# Altium schematic sheet TOP.SchDoc
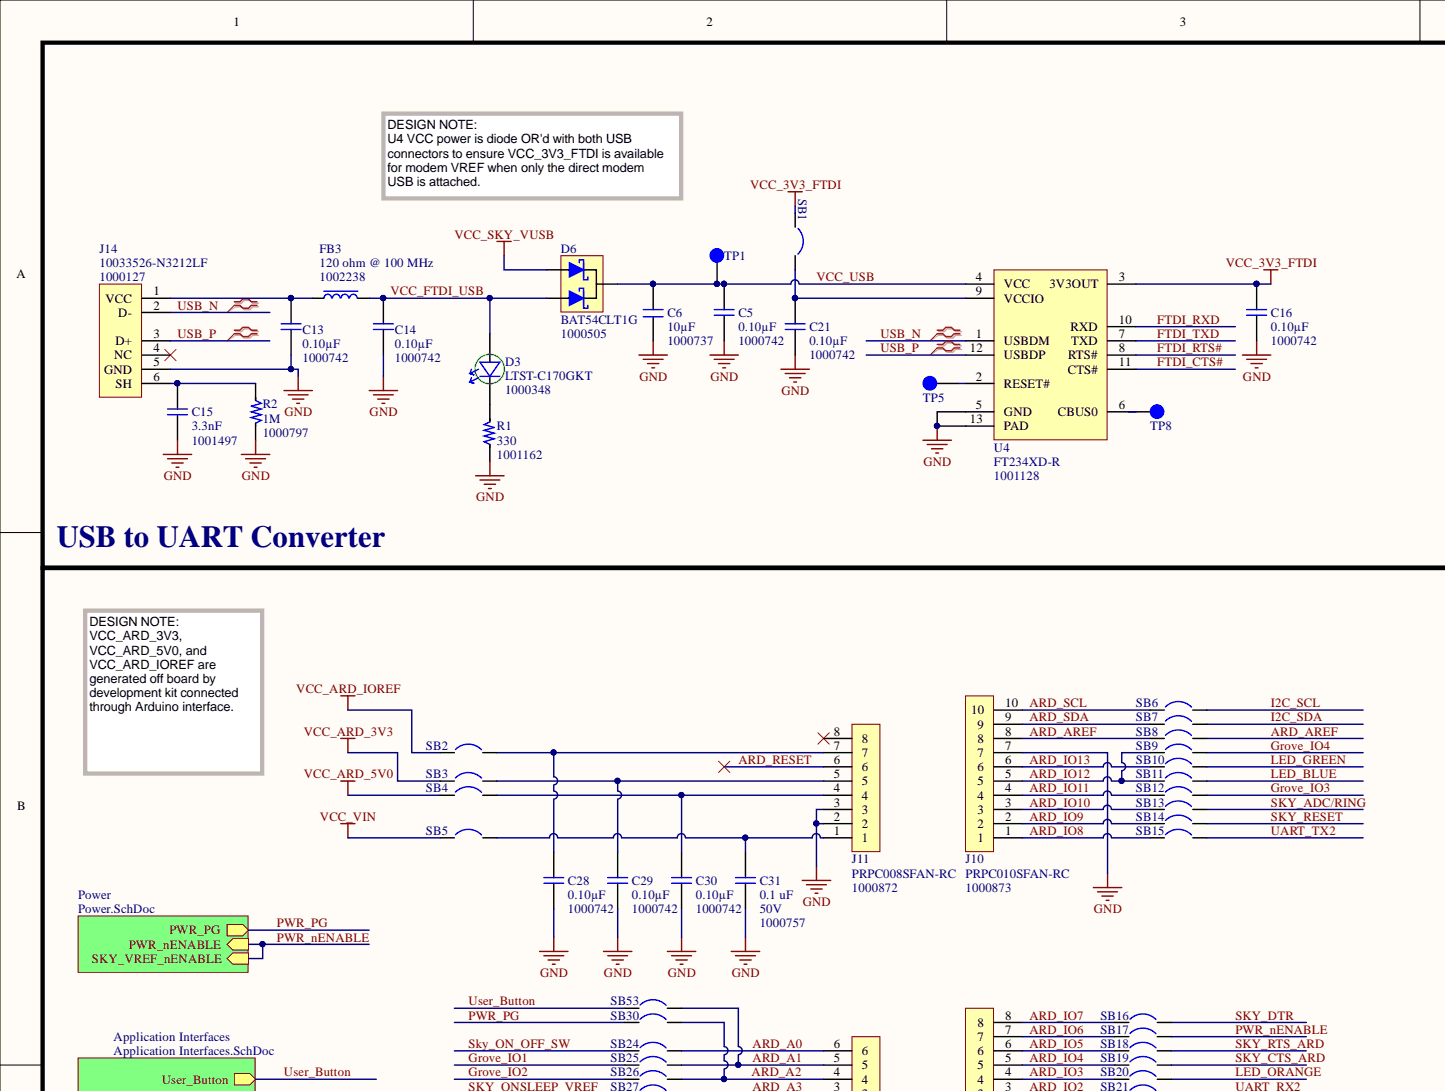
[diagram p0: TOP.SchDoc, top left]
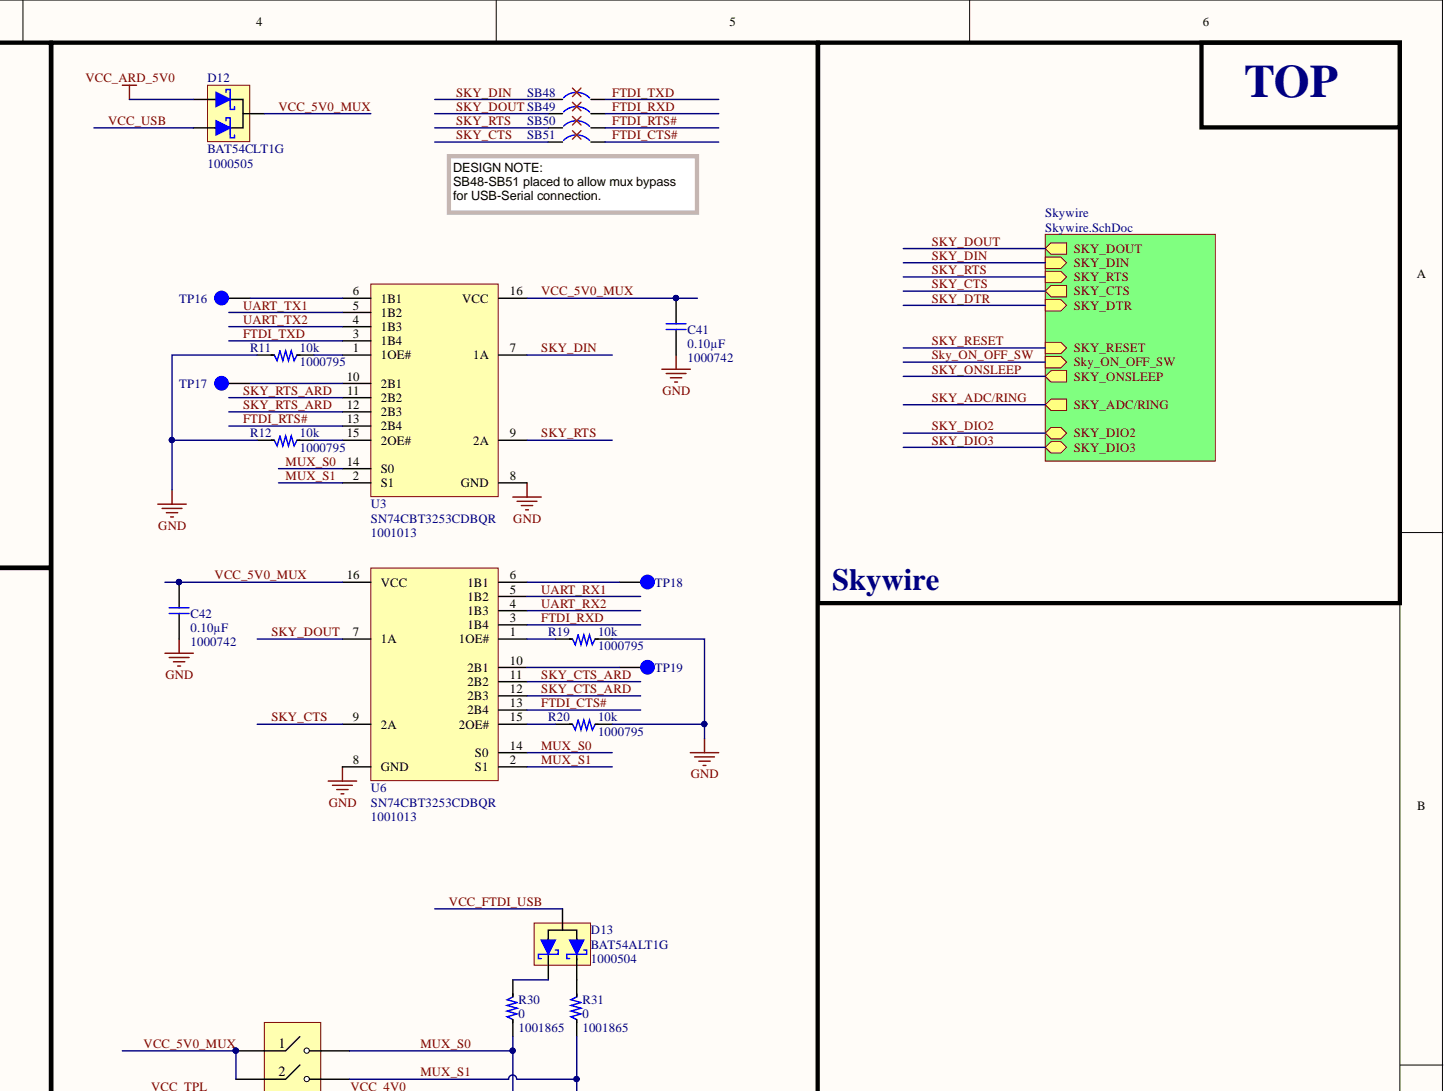
[diagram p1: TOP.SchDoc, top right]
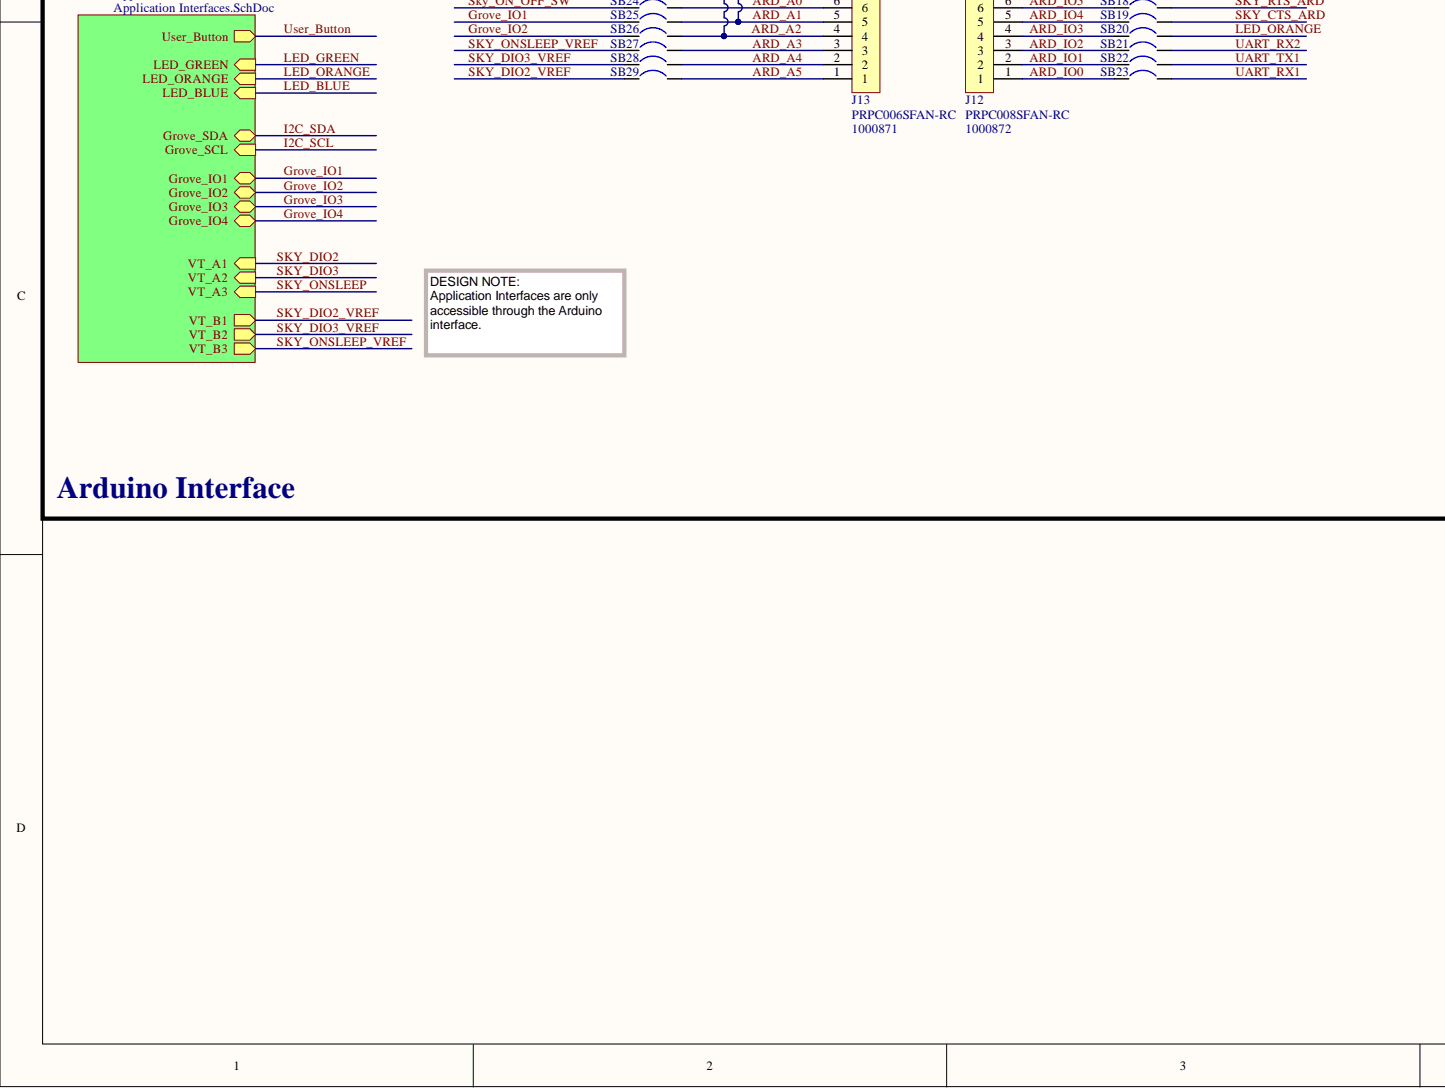
[diagram p2: TOP.SchDoc, bottom left]
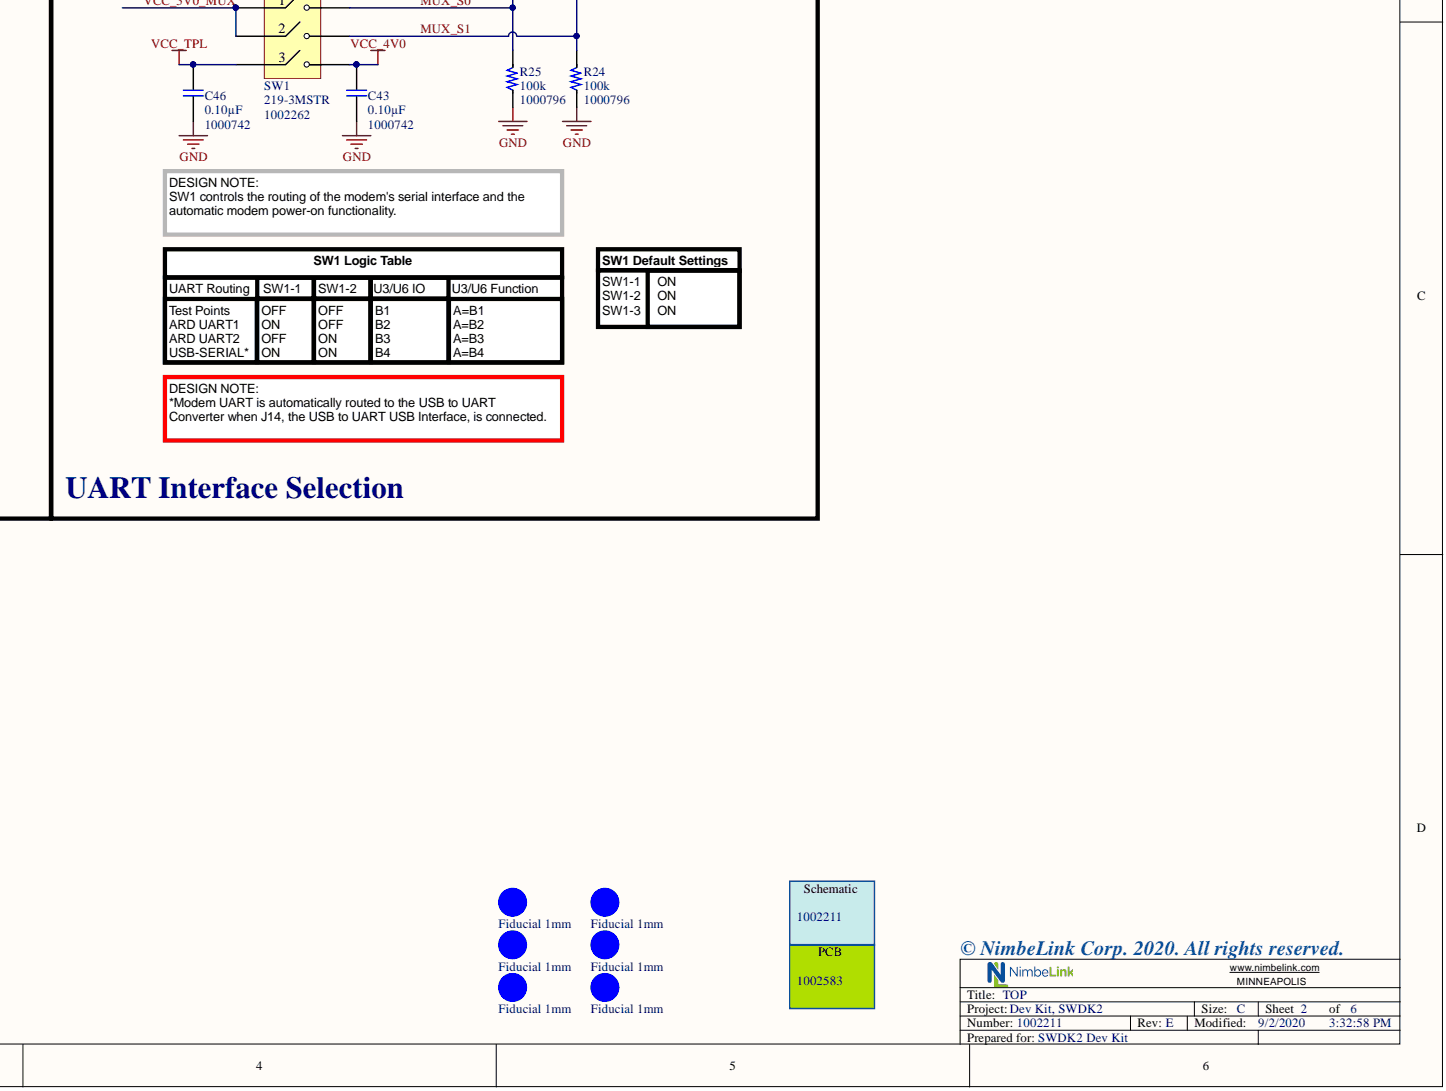
[diagram p3: TOP.SchDoc, bottom right]

## Parts
C5  Capacitance: 0.10µF  [0402]
C6  Capacitance: 10µF  [0402 +-0.2mm Width]  (hidden comment: C, 10 uF, 10V, X5R, 20%, 0402 CER)
C13  Capacitance: 0.10µF  [0402]
C14  Capacitance: 0.10µF  [0402]
C15  Capacitance: 3.3nF  [0402]  (hidden comment: CAPACITOR)
C16  Capacitance: 0.10µF  [0402]
C21  Capacitance: 0.10µF  [0402]
C28  Capacitance: 0.10µF  [0402]
C29  Capacitance: 0.10µF  [0402]
C30  Capacitance: 0.10µF  [0402]
C31  Capacitance: 0.1 uF  Voltage_Rating: 50V  [0603]  (hidden comment: CAPACITOR)
C41  Capacitance: 0.10µF  [0402]
C42  Capacitance: 0.10µF  [0402]
C43  Capacitance: 0.10µF  [0402]
C46  Capacitance: 0.10µF  [0402]
D3  LTST-C170GKT  [D_LED_GREEN]
D6  BAT54CLT1G  [SOT23-STYLE9]
D12  BAT54CLT1G  [SOT23-STYLE9]
D13  BAT54ALT1G  [SOT23-STYLE9]
FB3  FERRITE  [0603]  (value not drawn)
Fiducial1  Fiducial 1mm  [Fiducial 1mm]  (no BOM)
Fiducial2  Fiducial 1mm  [Fiducial 1mm]  (no BOM)
Fiducial3  Fiducial 1mm  [Fiducial 1mm]  (no BOM)
Fiducial4  Fiducial 1mm  [Fiducial 1mm]  (no BOM)
Fiducial5  Fiducial 1mm  [Fiducial 1mm]  (no BOM)
Fiducial6  Fiducial 1mm  [Fiducial 1mm]  (no BOM)
J10  PRPC010SFAN-RC  [PRPC010SFAN-RC]
J11  PRPC008SFAN-RC  [PRPC008SFAN-RC]
J12  PRPC008SFAN-RC  [PRPC008SFAN-RC]
J13  PRPC006SFAN-RC  [PRPC006SFAN-RC]
J14  USB MINI B  [10033526-N3212LF]  (value not drawn)
PCB1  PCB  Part_Number: 1002583  (mechanical)
R1  R, 330, 1%, 1/10W, 0402  [0402]  (value not drawn)
R2  Resistance_Ohms: 1M  [0402]  (hidden comment: R, 1M, 1%, 1/16W, 0402)
R11  Resistance_Ohms: 10k  [0402]  (hidden comment: R, 10K, 1%, 1/16W,  0402)
R12  Resistance_Ohms: 10k  [0402]  (hidden comment: R, 10K, 1%, 1/16W,  0402)
R19  Resistance_Ohms: 10k  [0402]  (hidden comment: R, 10K, 1%, 1/16W,  0402)
R20  Resistance_Ohms: 10k  [0402]  (hidden comment: R, 10K, 1%, 1/16W,  0402)
R24  Resistance_Ohms: 100k  [0402]  (hidden comment: R, 100K, 1%, 1/16W,  0402)
R25  Resistance_Ohms: 100k  [0402]  (hidden comment: R, 100K, 1%, 1/16W,  0402)
R30  RESISTOR  [0402]  (value not drawn)
R31  RESISTOR  [0402]  (value not drawn)
SB1  Solder Bridge  [Solder Bridge 0603 Closed]  (value not drawn)
SB2  Solder Bridge  [Solder Bridge 0603 Closed]  (value not drawn)
SB3  Solder Bridge  [Solder Bridge 0603 Closed]  (value not drawn)
SB4  Solder Bridge  [Solder Bridge 0603 Closed]  (value not drawn)
SB5  Solder Bridge  [Solder Bridge 0603 Closed]  (value not drawn)
SB6  Solder Bridge  [Solder Bridge 0603 Closed]  (value not drawn)
SB7  Solder Bridge  [Solder Bridge 0603 Closed]  (value not drawn)
SB8  Solder Bridge  [Solder Bridge 0603 Closed]  (value not drawn)
SB9  Solder Bridge  [Solder Bridge 0603 Closed]  (value not drawn)
SB10  Solder Bridge  [Solder Bridge 0603 Closed]  (value not drawn)
SB11  Solder Bridge  [Solder Bridge 0603 Closed]  (value not drawn)
SB12  Solder Bridge  [Solder Bridge 0603 Closed]  (value not drawn)
SB13  Solder Bridge  [Solder Bridge 0603 Closed]  (value not drawn)
SB14  Solder Bridge  [Solder Bridge 0603 Closed]  (value not drawn)
SB15  Solder Bridge  [Solder Bridge 0603 Closed]  (value not drawn)
SB16  Solder Bridge  [Solder Bridge 0603 Closed]  (value not drawn)
SB17  Solder Bridge  [Solder Bridge 0603 Closed]  (value not drawn)
SB18  Solder Bridge  [Solder Bridge 0603 Closed]  (value not drawn)
SB19  Solder Bridge  [Solder Bridge 0603 Closed]  (value not drawn)
SB20  Solder Bridge  [Solder Bridge 0603 Closed]  (value not drawn)
SB21  Solder Bridge  [Solder Bridge 0603 Closed]  (value not drawn)
SB22  Solder Bridge  [Solder Bridge 0603 Closed]  (value not drawn)
SB23  Solder Bridge  [Solder Bridge 0603 Closed]  (value not drawn)
SB24  Solder Bridge  [Solder Bridge 0603 Closed]  (value not drawn)
SB25  Solder Bridge  [Solder Bridge 0603 Closed]  (value not drawn)
SB26  Solder Bridge  [Solder Bridge 0603 Closed]  (value not drawn)
SB27  Solder Bridge  [Solder Bridge 0603 Closed]  (value not drawn)
SB28  Solder Bridge  [Solder Bridge 0603 Closed]  (value not drawn)
SB29  Solder Bridge  [Solder Bridge 0603 Closed]  (value not drawn)
SB30  Solder Bridge  [Solder Bridge 0603 Closed]  (value not drawn)
SB48  Solder Bridge  [SOLDER BRIDGE 0603]  (value not drawn)  (no BOM)
SB49  Solder Bridge  [SOLDER BRIDGE 0603]  (value not drawn)  (no BOM)
SB50  Solder Bridge  [SOLDER BRIDGE 0603]  (value not drawn)  (no BOM)
SB51  Solder Bridge  [SOLDER BRIDGE 0603]  (value not drawn)  (no BOM)
SB53  Solder Bridge  [Solder Bridge 0603 Closed]  (value not drawn)
SCH1  Part_Number: 1002211  (hidden comment: SCH)  (mechanical)
SW1  DIP-3pin  [219-3MSTR]  (value not drawn)
TP1  Test Point 1mm  [Test_Point 1mm]  (value not drawn)  (no BOM)
TP5  Test Point 1mm  [Test_Point 1mm]  (value not drawn)  (no BOM)
TP8  Test Point 1mm  [Test_Point 1mm]  (value not drawn)  (no BOM)
TP16  Test Point 1mm  [Test_Point 1mm]  (value not drawn)  (no BOM)
TP17  Test Point 1mm  [Test_Point 1mm]  (value not drawn)  (no BOM)
TP18  Test Point 1mm  [Test_Point 1mm]  (value not drawn)  (no BOM)
TP19  Test Point 1mm  [Test_Point 1mm]  (value not drawn)  (no BOM)
U3  SN74CBT3253CDBQR  [SN74CBT3253CDBQR]
U4  FT234XD  Manufacturer_Part_Number1: FT234XD-R  [QFN45P300X300X80_HS-13N]
U6  SN74CBT3253CDBQR  [SN74CBT3253CDBQR]

## Nets
GND: C5.2 C6.2 C13.2 C14.2 C15.1 C16.2 C21.2 C28.2 C29.2 C30.2 C31.2 C41.2 C42.2 C43.2 C46.2 J10.7 J11.2 J11.3 J14.5(GND) R1.1 R2.1 R11.2 R12.2 R19.1 R20.1 R24.1 R25.1 U3.8(GND) U4.5(GND) U4.13(PAD) U6.8(GND)
VCC_3V3_FTDI: C16.1 SB1.1 U4.3(3V3OUT)
VCC_4V0: C43.1 SW1.4
VCC_ARD_3V3: SB3.1
VCC_ARD_5V0: D12.2 SB4.1
VCC_ARD_IOREF: SB2.1
VCC_SKY_VUSB: D6.2
VCC_TPL: C46.1 SW1.3
VCC_VIN: SB5.1
ARD_A0: J13.6 SB24.2
ARD_A1: J13.5 SB25.2 SB53.2
ARD_A2: J13.4 SB26.2 SB30.2
ARD_A3: J13.3 SB27.2
ARD_A4: J13.2 SB28.2
ARD_A5: J13.1 SB29.2
ARD_AREF: J10.8 SB8.1 SB8.2
ARD_IO0: J12.1 SB23.1
ARD_IO1: J12.2 SB22.1
ARD_IO2: J12.3 SB21.1
ARD_IO3: J12.4 SB20.1
ARD_IO4: J12.5 SB19.1
ARD_IO5: J12.6 SB18.1
ARD_IO6: J12.7 SB17.1
ARD_IO7: J12.8 SB16.1
ARD_IO8: J10.1 SB15.1
ARD_IO9: J10.2 SB14.1
ARD_IO10: J10.3 SB13.1
ARD_IO11: J10.4 SB12.1
ARD_IO12: J10.5 SB9.1 SB11.1
ARD_IO13: J10.6 SB10.1
ARD_RESET: J11.6
ARD_SCL: J10.10 SB6.1
ARD_SDA: J10.9 SB7.1
FTDI_CTS#: SB51.2 U4.11(CTS#) U6.13(2B4)
FTDI_RTS#: SB50.2 U3.13(2B4) U4.8(RTS#)
FTDI_RXD: SB49.2 U4.10(RXD) U6.3(1B4)
FTDI_TXD: SB48.2 U3.3(1B4) U4.7(TXD)
Grove_IO1: SB25.1
Grove_IO2: SB26.1
Grove_IO3: SB12.2
Grove_IO4: SB9.2
I2C_SCL: SB6.2
I2C_SDA: SB7.2
LED_BLUE: SB11.2
LED_GREEN: SB10.2
LED_ORANGE: SB20.2
MUX_S0: R25.2 R30.1 SW1.6 U3.14(S0) U6.14(S0)
MUX_S1: R24.2 R31.1 SW1.5 U3.2(S1) U6.2(S1)
PWR_nENABLE: SB17.2
PWR_PG: SB30.1
SKY_ADC/RING: SB13.2
SKY_CTS: SB51.1 U6.9(2A)
SKY_CTS_ARD: SB19.2 U6.11(2B2) U6.12(2B3)
SKY_DIN: SB48.1 U3.7(1A)
SKY_DIO2_VREF: SB29.1
SKY_DIO3_VREF: SB28.1
SKY_DOUT: SB49.1 U6.7(1A)
SKY_DTR: SB16.2
Sky_ON_OFF_SW: SB24.1
SKY_ONSLEEP_VREF: SB27.1
SKY_RESET: SB14.2
SKY_RTS: SB50.1 U3.9(2A)
SKY_RTS_ARD: SB18.2 U3.11(2B2) U3.12(2B3)
UART_RX1: SB23.2 U6.5(1B2)
UART_RX2: SB21.2 U6.4(1B3)
UART_TX1: SB22.2 U3.5(1B2)
UART_TX2: SB15.2 U3.4(1B3)
USB_N: J14.2(D-) U4.1(USBDM)
USB_P: J14.3(D+) U4.12(USBDP)
User_Button: SB53.1
VCC_5V0_MUX: C41.1 C42.1 D12.3 SW1.1 SW1.2 U3.16(VCC) U6.16(VCC)
VCC_FTDI_USB: C14.1 D3.1 D6.1 D13.3 FB3.2
VCC_USB: C5.1 C6.1 D6.3 D12.1 TP1.1 U4.4(VCC)
NetC13_1: C13.1 FB3.1 J14.1(VCC)
NetC15_2: C15.2 J14.6(SH) R2.2
NetC21_1: C21.1 SB1.2 U4.9(VCCIO)
NetC28_1: C28.1 J11.7 SB2.2
NetC29_1: C29.1 J11.5 SB3.2
NetC30_1: C30.1 J11.4 SB4.2
NetC31_1: C31.1 J11.1 SB5.2
NetD3_2: D3.2 R1.2
NetD13_1: D13.1 R30.2
NetD13_2: D13.2 R31.2
NetJ11_8: J11.8
NetJ14_4: J14.4(NC)
NetR11_1: R11.1 U3.1(1OE#)
NetR12_1: R12.1 U3.15(2OE#)
NetR19_2: R19.2 U6.1(1OE#)
NetR20_2: R20.2 U6.15(2OE#)
NetTP5_1: TP5.1 U4.2(RESET#)
NetTP8_1: TP8.1 U4.6(CBUS0)
NetTP16_1: TP16.1 U3.6(1B1)
NetTP17_1: TP17.1 U3.10(2B1)
NetTP18_1: TP18.1 U6.6(1B1)
NetTP19_1: TP19.1 U6.10(2B1)
SKY_DIO2:
SKY_DIO3:
SKY_ONSLEEP:

## Library data of the parts
C5 C13 C14 C16 C21 C28-C30 C41-C43 C46 = 1000742: Capacitor, 0.1 uF, 16V, 10%, X7R, 0402; Manufacturer1: Kemet; Manufacturer_Part_Number1: C0402C104K4RALTU; Capacitor_Type: Ceramic; Item_Phase: Production; Mounting_Type: Surface Mount; Operating_Temperature: -55°C ~ 125°C; Thickness_Max: 0.022" (0.55mm); Applications: General Purpose; Bom_View: https://app.bom.com/a/YGZ57I1I1KJQDBOE?v=bom.indented; Component Kind: Standard; Costing_View: https://app.bom.com/a/YGZ57I1I1KJQDBOE?v=costing.summary; Database Table Name: Capacitor; Datasheet_Link: http://www.samsungsem.com/kr/support/product-search/mlcc/CL05B104KO5NNNC.jsp; Distributor1: Digi-Key; Distributor2: Digi-Key; Distributor_Part_Number1: 399-6342-1-ND; Distributor_Part_Number2: 1276-1001-1-ND; Files_View: https://app.bom.com/a/YGZ57I1I1KJQDBOE?v=files.associations; Library Name: AltiumProductionDatabase.DbLib; Manufacturer2: Samsung Electro-Mechanics America, Inc; Manufacturer_Part_Number2: CL05B104KO5NNNC; Package_Case: 0402 (1005 Metric); Part_Number: 1000742; Part_Type: Capacitor; Revision: A; Size_Dimension: 0.039" L x 0.020" W (1.00mm x 0.50mm); Sourcing_View: https://app.bom.com/a/YGZ57I1I1KJQDBOE?v=sourcing.relationships; Specs_View: https://app.bom.com/a/YGZ57I1I1KJQDBOE?v=spec.summary; Temperature_Coefficient: X7R; Tolerance: ±10%; Voltage_Rating: 16V
C6 = 1000737: Capacitor, 10 uF, 10V, 20%, X5R, 0402; Manufacturer1: Venkel LTD.; Manufacturer_Part_Number1: C0402X5R100-106MNP; Capacitor_Type: Ceramic; Item_Phase: Production; Mounting_Type: Surface Mount; Operating_Temperature: -55°C ~ 85°C; Thickness_Max: 0.035" (0.90mm); Applications: General Purpose; Bom_View: https://app.bom.com/a/TBU02DWDWFEL86JJ?v=bom.indented; Component Kind: Standard; Costing_View: https://app.bom.com/a/TBU02DWDWFEL86JJ?v=costing.summary; Database Table Name: Capacitor; Datasheet_Link: http://www.samsungsem.com/kr/support/product-search/mlcc/CL05A106MP8NUB8.jsp; Distributor1: Venkel LTD.; Distributor2: Digi-Key; Distributor_Part_Number1: C0402X5R100-106MNP; Distributor_Part_Number2: 1276-6830-1-ND; Files_View: https://app.bom.com/a/TBU02DWDWFEL86JJ?v=files.associations; Library Name: AltiumProductionDatabase.DbLib; Manufacturer2: Samsung Electro-Mechanics America, Inc; Manufacturer_Part_Number2: CL05A106MP8NUB8; Package_Case: 0402 (1005 Metric); Part_Number: 1000737; Part_Type: Capacitor; Revision: B; Size_Dimension: 0.039" L x 0.020" W (1.00mm x 0.50mm); Sourcing_View: https://app.bom.com/a/TBU02DWDWFEL86JJ?v=sourcing.relationships; Specs_View: https://app.bom.com/a/TBU02DWDWFEL86JJ?v=spec.summary; Temperature_Coefficient: X5R; Tolerance: ±20%; Voltage_Rating: 10V
C15 = 1001497: Capacitor, 3.3 nF, 50V, 10%, X7R, 0402; Manufacturer1: Murata; Manufacturer_Part_Number1: GRM155R71H332KA01D; Capacitor_Type: Ceramic; Item_Phase: Production; Mounting_Type: Surface Mount; Operating_Temperature: -55°C ~ 125°C; Thickness_Max: 0.022" (0.55mm); Bom_View: https://app.bom.com/a/WEX35GZGZIHJRTPV?v=bom.indented; Component Kind: Standard; Costing_View: https://app.bom.com/a/WEX35GZGZIHJRTPV?v=costing.summary; Database Table Name: Capacitor; Distributor1: Digi-Key; Distributor_Part_Number1: 490-3248-1-ND; Files_View: https://app.bom.com/a/WEX35GZGZIHJRTPV?v=files.associations; Library Name: AltiumProductionDatabase.DbLib; Package_Case: 0402; Part_Number: 1001497; Part_Type: Capacitor; Revision: A; Size_Dimension: 0.039" L x 0.020" W (1.00mm x 0.50mm); Sourcing_View: https://app.bom.com/a/WEX35GZGZIHJRTPV?v=sourcing.relationships; Specs_View: https://app.bom.com/a/WEX35GZGZIHJRTPV?v=spec.summary; Temperature_Coefficient: X7R; Tolerance: 10%; Voltage_Rating: 50V
C31 = 1000757: Capacitor, 0.1 uF, 50V, 10%, X7R, 0603; Manufacturer1: TDK Corporation; Manufacturer_Part_Number1: CGA3E2X7R1H104K080AA; Capacitor_Type: Ceramic; Item_Phase: Production; Mounting_Type: Surface Mount; Operating_Temperature: -55 to 125C; Bom_View: https://app.bom.com/a/EWFLNYHYH0Z6TR4O?v=bom.indented; Component Kind: Standard; Costing_View: https://app.bom.com/a/EWFLNYHYH0Z6TR4O?v=costing.summary; Database Table Name: Capacitor; Datasheet_Link: http://www.samsungsem.com/kr/support/product-search/mlcc/CL10B104KB8SFNC.jsp; Distributor1: Digi-Key; Distributor2: Digi-Key; Distributor_Part_Number1: 445-5666-1-ND; Distributor_Part_Number2: 478-5052-2-ND; Files_View: https://app.bom.com/a/EWFLNYHYH0Z6TR4O?v=files.associations; Library Name: AltiumProductionDatabase.DbLib; Manufacturer2: AVX Corporation; Manufacturer_Part_Number2: 06035C104KAT2A; Package_Case: 0603; Part_Number: 1000757; Part_Type: Capacitor; Revision: A; Sourcing_View: https://app.bom.com/a/EWFLNYHYH0Z6TR4O?v=sourcing.relationships; Specs_View: https://app.bom.com/a/EWFLNYHYH0Z6TR4O?v=spec.summary; Tolerance: 10%
D3 = 1000348: Diode, LED, Green, 6mcd, 569nm, 0805; Manufacturer1: Lite-On Inc; Item_Phase: Production; Bom_View: https://app.bom.com/a/J1KQS3M3M54BYXUP?v=bom.indented; Color: Green; Component Kind: Standard; Costing_View: https://app.bom.com/a/J1KQS3M3M54BYXUP?v=costing.summary; Database Table Name: LED; Datasheet_Link: http://www.mouser.com/catalog/specsheets/Lite-On-LTST-C170GKT.pdf; Distributor1: Digi-Key; Distributor_Part_Number1: 160-1179-1-ND; Files_View: https://app.bom.com/a/J1KQS3M3M54BYXUP?v=files.associations; Library Name: AltiumProductionDatabase.DbLib; Manufacturer_Part_Number1: LTST-C170GKT; Package_Case: 0805 (2012 Metric); Part_Number: 1000348; Part_Type: LED; Revision: A; Sourcing_View: https://app.bom.com/a/J1KQS3M3M54BYXUP?v=sourcing.relationships; Specs_View: https://app.bom.com/a/J1KQS3M3M54BYXUP?v=spec.summary; Voltage_Forward_VF_Typical: -
D6 D12 = 1000505: Diode, Schottky, Dual, COM CATHODE, 30V, 0.35VF, 200MA, SOT23; Manufacturer1: ON Semiconductor; Current_Average_Rectified_IO: 200mA (DC); Current_Reverse_Leakage_at_Vref: 2µA @ 25V; Item_Phase: Production; Mounting_Type: Surface Mount; Voltage_Forward_VF_Max_at_I_Forward: 800mV @ 100mA; Bom_View: https://app.bom.com/a/DVEKMXGXGZY5SRH8?v=bom.indented; Component Kind: Standard; Costing_View: https://app.bom.com/a/DVEKMXGXGZY5SRH8?v=costing.summary; Database Table Name: Diode; Datasheet_Link: http://www.onsemi.com/pub_link/Collateral/BAT54CLT1-D.PDF; Diode_Type: Schottky; Distributor1: Digi-Key; Distributor_Part_Number1: BAT54CLT1GOSCT-ND; Files_View: https://app.bom.com/a/DVEKMXGXGZY5SRH8?v=files.associations; Library Name: AltiumProductionDatabase.DbLib; Manufacturer_Part_Number1: BAT54CLT1G; Package_Case: TO-236-3, SC-59, SOT-23-3; Part_Number: 1000505; Part_Type: Diode; Revision: A; Sourcing_View: https://app.bom.com/a/DVEKMXGXGZY5SRH8?v=sourcing.relationships; Specs_View: https://app.bom.com/a/DVEKMXGXGZY5SRH8?v=spec.summary; Voltage_DC_Reverse_VR_Max: 30V
D13 = 1000504: Diode, Schottky, Dual, COM ANODE, 30V, 0.35 Vf, 200MA, SOT23; Manufacturer1: ON Semiconductor; Current_Average_Rectified_IO: 200mA (DC); Current_Reverse_Leakage_at_Vref: 2µA @ 25V; Item_Phase: Production; Mounting_Type: Surface Mount; Voltage_Forward_VF_Max_at_I_Forward: 800mV @ 100mA; Bom_View: https://app.bom.com/a/BTCIKVEVEXW3QPGJ?v=bom.indented; Component Kind: Standard; Costing_View: https://app.bom.com/a/BTCIKVEVEXW3QPGJ?v=costing.summary; Database Table Name: Diode; Datasheet_Link: http://www.onsemi.com/pub_link/Collateral/BAT54ALT1-D.PDF; Diode_Type: Schottky; Distributor1: Digi-Key; Distributor_Part_Number1: BAT54ALT1GOSCT-ND; Files_View: https://app.bom.com/a/BTCIKVEVEXW3QPGJ?v=files.associations; Library Name: AltiumProductionDatabase.DbLib; Manufacturer_Part_Number1: BAT54ALT1G; Package_Case: TO-236-3, SC-59, SOT-23-3; Part_Number: 1000504; Part_Type: Diode; Revision: A; Sourcing_View: https://app.bom.com/a/BTCIKVEVEXW3QPGJ?v=sourcing.relationships; Specs_View: https://app.bom.com/a/BTCIKVEVEXW3QPGJ?v=spec.summary; Voltage_DC_Reverse_VR_Max: 30V
FB3 = 1002238: Ferrite Bead, 120 ohm @100MHz, 3A, 30mOHM-DC, 0603; Manufacturer1: Samsung Electro-Mechanics America, Inc; Manufacturer_Part_Number1: CIS10P121AC; Item_Phase: Production; Mounting_Type: Surface Mount; Operating_Temperature: -55°C ~ 125°C; Resistance_DC: 30 mOhm; Bom_View: https://app.bom.com/a/J1KQS3M3M53JVBL9?v=bom.indented; Component Kind: Standard; Costing_View: https://app.bom.com/a/J1KQS3M3M53JVBL9?v=costing.summary; Database Table Name: Ferrite; Distributor1: Digi-Key; Distributor2: Arrow; Distributor_Part_Number1: 1276-6351-2-ND; Distributor_Part_Number2: Murata Electronics North America BLM18KG121TN1D; Files_View: https://app.bom.com/a/J1KQS3M3M53JVBL9?v=files.associations; Library Name: AltiumProductionDatabase.DbLib; Manufacturer2: Murata Electronics North America; Manufacturer_Part_Number2: BLM18KG121TN1D; Package_Case: 0603; Part_Number: 1002238; Part_Type: Ferrite; Resistance_at_Frequency: 120 ohm @ 100 MHz; Revision: A; Sourcing_View: https://app.bom.com/a/J1KQS3M3M53JVBL9?v=sourcing.relationships; Specs_View: https://app.bom.com/a/J1KQS3M3M53JVBL9?v=spec.summary
Fiducial1-Fiducial6 = Fiducial: Population: NP; Description: Fiducial 1mm; Library Name: Misc_SCH_Lib.SchLib
J10 = 1000873: Connector, Header, Male, 2.54mm, 10x1, TH 8.08mm; Manufacturer1: Sullins Connector Solutions; Connector_Type: Header, Unshrouded, Breakaway; Contact_Finish: Gold; Contact_Type: Male Pin; Item_Phase: Production; Mounting_Type: Through Hole; Number_of_Positions: 10; Number_of_Positions_Loaded: All; Number_of_Rows: 1; Pitch: 0.100" (2.54mm); Bom_View: https://app.bom.com/a/ASBHJUDUDWV2PNTB?v=bom.indented; Color: Black; Component Kind: Standard; Costing_View: https://app.bom.com/a/ASBHJUDUDWV2PNTB?v=costing.summary; Database Table Name: Connector; Datasheet_Link: http://media.digikey.com/PDF/Data%20Sheets/Sullins%20PDFs/xRxCzzzSxxN-RC_ST_11635-B.pdf; Distributor1: Digi-Key; Distributor_Part_Number1: S1211EC-10-ND; Files_View: https://app.bom.com/a/ASBHJUDUDWV2PNTB?v=files.associations; Library Name: AltiumProductionDatabase.DbLib; Manufacturer_Part_Number1: PRPC010SFAN-RC; Part_Number: 1000873; Part_Type: Connector; Revision: A; Sourcing_View: https://app.bom.com/a/ASBHJUDUDWV2PNTB?v=sourcing.relationships; Specs_View: https://app.bom.com/a/ASBHJUDUDWV2PNTB?v=spec.summary; Termination: Solder
J11 J12 = 1000872: Connector, Header, Male, 2.54mm, 8x1, TH, 8.08mm; Manufacturer1: Sullins Connector Solutions; Connector_Type: Header, Unshrouded, Breakaway; Contact_Finish: Gold; Contact_Type: Male Pin; Item_Phase: Production; Mounting_Type: Through Hole; Number_of_Positions: 8; Number_of_Positions_Loaded: All; Number_of_Rows: 1; Pitch: 0.100" (2.54mm); Bom_View: https://app.bom.com/a/9RAGITCTCVU1OMSH?v=bom.indented; Color: Black; Component Kind: Standard; Costing_View: https://app.bom.com/a/9RAGITCTCVU1OMSH?v=costing.summary; Database Table Name: Connector; Datasheet_Link: http://media.digikey.com/PDF/Data%20Sheets/Sullins%20PDFs/xRxCzzzSxxN-RC_ST_11635-B.pdf; Distributor1: Digi-Key; Distributor_Part_Number1: S1211EC-08-ND; Files_View: https://app.bom.com/a/9RAGITCTCVU1OMSH?v=files.associations; Library Name: AltiumProductionDatabase.DbLib; Manufacturer_Part_Number1: PRPC008SFAN-RC; Part_Number: 1000872; Part_Type: Connector; Revision: A; Sourcing_View: https://app.bom.com/a/9RAGITCTCVU1OMSH?v=sourcing.relationships; Specs_View: https://app.bom.com/a/9RAGITCTCVU1OMSH?v=spec.summary; Termination: Solder
J13 = 1000871: Connector, Header, Male, 2.54mm, 6x1, TH, 8.08mm; Manufacturer1: Sullins Connector Solutions; Connector_Type: Header, Unshrouded, Breakaway; Contact_Finish: Gold; Contact_Type: Male Pin; Item_Phase: Production; Mounting_Type: Through Hole; Number_of_Positions: 6; Number_of_Positions_Loaded: All; Number_of_Rows: 1; Pitch: 0.100" (2.54mm); Bom_View: https://app.bom.com/a/7P8EGRARATSZMKQD?v=bom.indented; Color: Black; Component Kind: Standard; Costing_View: https://app.bom.com/a/7P8EGRARATSZMKQD?v=costing.summary; Database Table Name: Connector; Datasheet_Link: http://media.digikey.com/PDF/Data%20Sheets/Sullins%20PDFs/xRxCzzzSxxN-RC_ST_11635-B.pdf; Distributor1: Digi-Key; Distributor_Part_Number1: S1211EC-06-ND; Files_View: https://app.bom.com/a/7P8EGRARATSZMKQD?v=files.associations; Library Name: AltiumProductionDatabase.DbLib; Manufacturer_Part_Number1: PRPC006SFAN-RC; Part_Number: 1000871; Part_Type: Connector; Revision: A; Sourcing_View: https://app.bom.com/a/7P8EGRARATSZMKQD?v=sourcing.relationships; Specs_View: https://app.bom.com/a/7P8EGRARATSZMKQD?v=spec.summary; Termination: Solder
J14 = 1000127: Connector, USB, Mini B, Right Angle, SMD; Manufacturer1: Amphenol; Connector_Type: USB - mini B; Gender: Receptacle; Item_Phase: Production; Mounting_Type: Surface Mount Right Angle Horizontal; Number_of_Pins: 5; Bom_View: https://app.bom.com/a/M4NTV6P6P87E104T?v=bom.indented; Component Kind: Standard; Costing_View: https://app.bom.com/a/M4NTV6P6P87E104T?v=costing.summary; Database Table Name: Connector; Datasheet_Link: http://portal.fciconnect.com/Comergent//fci/drawing/10033526.pdf; Distributor1: Digi-Key; Distributor_Part_Number1: 609-4700-1-ND; Files_View: https://app.bom.com/a/M4NTV6P6P87E104T?v=files.associations; Library Name: AltiumProductionDatabase.DbLib; Manufacturer_Part_Number1: 10033526-N3212LF; Part_Number: 1000127; Part_Type: Connector; Revision: A; Sourcing_View: https://app.bom.com/a/M4NTV6P6P87E104T?v=sourcing.relationships; Specs_View: https://app.bom.com/a/M4NTV6P6P87E104T?v=spec.summary
PCB1 = PCB: PCB Part Number; Library Name: Misc_SCH_Lib.SchLib
R1 = 1001162: Resistor, 330, 1%, 1/10W, 0402; Manufacturer1: Panasonic Electronic Components; Manufacturer_Part_Number1: ERJ-2RKF3300X; Item_Phase: Production; Mounting_Type: Surface Mount; Number_of_Terminations: 2; Operating_Temperature: -55°C ~ 155°C; Power: 0.1W, 1/10W; Resistance_Ohms: 330; Resistor_Package: 0402 (1005 Metric); Temperature_Coefficient: ±100ppm/°C; Bom_View: https://app.bom.com/a/1J28AL4L4NMTGF0H?v=bom.indented; Component Kind: Standard; Composition: Thick Film; Costing_View: https://app.bom.com/a/1J28AL4L4NMTGF0H?v=costing.summary; Database Table Name: Resistor; Datasheet_Link: http://industrial.panasonic.com/www-cgi/jvcr13pz.cgi?E+PZ+3+AOA0002+ERJ2RKF3300X+7+WW; Distributor1: Digi-Key; Distributor_Part_Number1: P330LCT-ND; Features: Automotive AEC-Q200; Files_View: https://app.bom.com/a/1J28AL4L4NMTGF0H?v=files.associations; Height: 0.016" (0.40mm); Library Name: AltiumProductionDatabase.DbLib; Part_Number: 1001162; Part_Type: Resistor; Revision: A; Size_Dimension: 0.039" L x 0.020" W (1.00mm x 0.50mm); Sourcing_View: https://app.bom.com/a/1J28AL4L4NMTGF0H?v=sourcing.relationships; Specs_View: https://app.bom.com/a/1J28AL4L4NMTGF0H?v=spec.summary; Tolerance: ±1%
R2 = 1000797: Resistor, 1M, 1%, 1/16W, 0402; Manufacturer1: Samsung Electro-Mechanics America, Inc; Manufacturer_Part_Number1: RC1005F105CS; Item_Phase: Production; Mounting_Type: Surface Mount; Number_of_Terminations: 2; Operating_Temperature: -55°C ~ 155°C; Power: 0.063W, 1/16W; Resistor_Package: 0402 (1005 Metric); Temperature_Coefficient: ±100ppm/°C; Bom_View: https://app.bom.com/a/R9SY0BUBUDCJ64DV?v=bom.indented; Component Kind: Standard; Composition: Thick Film; Costing_View: https://app.bom.com/a/R9SY0BUBUDCJ64DV?v=costing.summary; Database Table Name: Resistor; Datasheet_Link: http://media.digikey.com/pdf/Data%20Sheets/Samsung%20PDFs/RC_Series_ds.pdf; Distributor1: Digi-Key; Distributor_Part_Number1: 1276-3433-1-ND; Features: Moisture Resistant; Files_View: https://app.bom.com/a/R9SY0BUBUDCJ64DV?v=files.associations; Height: 0.016" (0.40mm); Library Name: AltiumProductionDatabase.DbLib; Part_Number: 1000797; Part_Type: Resistor; Revision: A; Size_Dimension: 0.039" L x 0.020" W (1.00mm x 0.50mm); Sourcing_View: https://app.bom.com/a/R9SY0BUBUDCJ64DV?v=sourcing.relationships; Specs_View: https://app.bom.com/a/R9SY0BUBUDCJ64DV?v=spec.summary; Tolerance: ±1%
R11 R12 R19 R20 = 1000795: Resistor, 10K, 1%, 1/16W, 0402; Manufacturer1: Bourns Inc; Manufacturer_Part_Number1: CR0402-FX-1002GLF; Item_Phase: Production; Mounting_Type: Surface Mount; Number_of_Terminations: 2; Operating_Temperature: -55°C ~ 155°C; Power: 0.063W, 1/16W; Resistor_Package: 0402 (1005 Metric); Temperature_Coefficient: ±100ppm/°C; Bom_View: https://app.bom.com/a/P7QWY9S9SBAH42BP?v=bom.indented; Component Kind: Standard; Composition: Thick Film; Costing_View: https://app.bom.com/a/P7QWY9S9SBAH42BP?v=costing.summary; Database Table Name: Resistor; Datasheet_Link: http://media.digikey.com/pdf/Data%20Sheets/Samsung%20PDFs/RC_Series_ds.pdf; Distributor1: Digi-Key; Distributor2: Digi-Key; Distributor_Part_Number1: CR0402-FX-1002GLFTR-ND; Distributor_Part_Number2: CR0402-FX-1002GASTR-ND; Features: Moisture Resistant; Files_View: https://app.bom.com/a/P7QWY9S9SBAH42BP?v=files.associations; Height: 0.016" (0.40mm); Library Name: AltiumProductionDatabase.DbLib; Manufacturer2: Bourns Inc; Manufacturer_Part_Number2: CR0402-FX-1002GAS; Part_Number: 1000795; Part_Type: Resistor; Revision: A; Size_Dimension: 0.039" L x 0.020" W (1.00mm x 0.50mm); Sourcing_View: https://app.bom.com/a/P7QWY9S9SBAH42BP?v=sourcing.relationships; Specs_View: https://app.bom.com/a/P7QWY9S9SBAH42BP?v=spec.summary; Tolerance: ±1%
R24 R25 = 1000796: Resistor, 100K, 1%, 1/16W, 0402; Manufacturer1: Bourns Inc; Manufacturer_Part_Number1: CR0402-FX-1003GLF; Item_Phase: Production; Mounting_Type: Surface Mount; Number_of_Terminations: 2; Operating_Temperature: -55°C ~ 155°C; Power: 0.063W, 1/16W; Resistor_Package: 0402 (1005 Metric); Temperature_Coefficient: ±100ppm/°C; Bom_View: https://app.bom.com/a/Q8RXZATATCBI53CX?v=bom.indented; Component Kind: Standard; Composition: Thick Film; Costing_View: https://app.bom.com/a/Q8RXZATATCBI53CX?v=costing.summary; Database Table Name: Resistor; Datasheet_Link: http://media.digikey.com/pdf/Data%20Sheets/Samsung%20PDFs/RC_Series_ds.pdf; Distributor1: Digi-Key; Distributor2: Digi-Key; Distributor_Part_Number1: CR0402-FX-1003GLFTR-ND; Distributor_Part_Number2: 1276-3432-1-ND; Features: Moisture Resistant; Files_View: https://app.bom.com/a/Q8RXZATATCBI53CX?v=files.associations; Height: 0.016" (0.40mm); Library Name: AltiumProductionDatabase.DbLib; Manufacturer2: Samsung Electro-Mechanics America, Inc; Manufacturer_Part_Number2: RC1005F104CS; Part_Number: 1000796; Part_Type: Resistor; Revision: A; Size_Dimension: 0.039" L x 0.020" W (1.00mm x 0.50mm); Sourcing_View: https://app.bom.com/a/Q8RXZATATCBI53CX?v=sourcing.relationships; Specs_View: https://app.bom.com/a/Q8RXZATATCBI53CX?v=spec.summary; Tolerance: ±1%
R30 R31 = 1001865: Resistor, 0, 1/16W, 0402; Manufacturer1: Bourns Inc; Manufacturer_Part_Number1: CR0402-J/-000GLF; Item_Phase: Production; Mounting_Type: Surface Mount; Number_of_Terminations: 2; Operating_Temperature: -55C ~ 155C; Resistance_Ohms: 0; Resistor_Package: 0402 (1005 Metric); Bom_View: https://app.bom.com/a/K2LRT4N4N65WNVAP?v=bom.indented; Component Kind: Standard; Composition: Thick Film; Costing_View: https://app.bom.com/a/K2LRT4N4N65WNVAP?v=costing.summary; Database Table Name: Resistor; Distributor1: Digi-Key; Distributor2: Digi-Key; Distributor_Part_Number1: CR0402-J/-000GLFTR-ND; Distributor_Part_Number2: 1276-7013-2-ND; Files_View: https://app.bom.com/a/K2LRT4N4N65WNVAP?v=files.associations; Height: 0.40mm; Library Name: AltiumProductionDatabase.DbLib; Manufacturer2: Samsung Electro-Mechanics America, Inc; Manufacturer_Part_Number2: RCS1005J000CS; Part_Number: 1001865; Part_Type: Resistor; Power: 1/16W; Revision: A; Size_Dimension: 1.00mm x 0.50mm; Sourcing_View: https://app.bom.com/a/K2LRT4N4N65WNVAP?v=sourcing.relationships; Specs_View: https://app.bom.com/a/K2LRT4N4N65WNVAP?v=spec.summary
SB1-SB30 SB53 = 1002269: Resistor, Solder Bridge, 0, 1/10W, 0603; Manufacturer1: Panasonic Electronic Components; Manufacturer_Part_Number1: ERJ-3GEY0R00V; Item_Phase: Production; Mounting_Type: Surface Mount; Operating_Temperature: -55°C ~ 155°C; Resistance_Ohms: 0; Resistor_Package: 0603 (1608 Metric); Bom_View: https://app.bom.com/a/P7QWY9S9SB9PE986?v=bom.indented; Component Kind: Standard; Composition: Thick Film; Costing_View: https://app.bom.com/a/P7QWY9S9SB9PE986?v=costing.summary; Database Table Name: Resistor; Datasheet_Link: http://industrial.panasonic.com/www-cgi/jvcr13pz.cgi?E+PZ+3+AOA0001+ERJ3GEY0R00V+7+WW; Distributor1: Digi-Key; Distributor2: Digi-Key; Distributor_Part_Number1: P0.0GCT-ND; Distributor_Part_Number2: 311-0.0GRTR-ND; Files_View: https://app.bom.com/a/P7QWY9S9SB9PE986?v=files.associations; Library Name: AltiumProductionDatabase.DbLib; Manufacturer2: Yageo; Manufacturer_Part_Number2: RC0603JR-070RL; Part_Number: 1002269; Part_Type: Resistor; Power: 1/10W; Revision: A; Size_Dimension: 0.063" L x 0.031" W (1.60mm x 0.80mm); Sourcing_View: https://app.bom.com/a/P7QWY9S9SB9PE986?v=sourcing.relationships; Specs_View: https://app.bom.com/a/P7QWY9S9SB9PE986?v=spec.summary
SB48-SB51 = Solder Bridge, Open: Population: NP; Library Name: Misc_SCH_Lib.SchLib
SCH1 = SCH: Schematic Part Number; Library Name: Misc_SCH_Lib.SchLib
SW1 = 1002262: Switch, SPST, DIP 3, 20V, 0.1A, Washable, Raised Actuator, SMD; Manufacturer1: CTS; Actuator_Type: Slide; Item_Phase: Production; Mounting_Type: Surface Mount; Operating_Temperature: -55°C ~ 85°C; Bom_View: https://app.bom.com/a/7P8EGRARATR74156?v=bom.indented; Circuit: SPST; Component Kind: Standard; Contact_Current_Rating_at_Voltage: 0.1A; Costing_View: https://app.bom.com/a/7P8EGRARATR74156?v=costing.summary; Current_Rating: 0.1A; Database Table Name: Switch; Datasheet_Link: https://www.ctscorp.com/wp-content/uploads/219.pdf; Distributor1: Digi-Key; Distributor_Part_Number1: CT3094CT-ND; Files_View: https://app.bom.com/a/7P8EGRARATR74156?v=files.associations; Library Name: AltiumProductionDatabase.DbLib; Manufacturer_Part_Number1: 219-3MSTR; Outline_Dimensions: 9.09x9.91x4.05mm; Part_Number: 1002262; Part_Type: Switch; Revision: A; Sourcing_View: https://app.bom.com/a/7P8EGRARATR74156?v=sourcing.relationships; Specs_View: https://app.bom.com/a/7P8EGRARATR74156?v=spec.summary
TP1 TP5 TP8 TP16-TP19 = TP_1mm: TEST POINT, SMD 1mm; Population: NP; Library Name: Misc_SCH_Lib.SchLib
U3 U6 = 1001013: IC, Multiplexer, Dual, 4:1, 4.5V-5.5V, SSOP-16; Manufacturer1: Texas Instruments; Item_Phase: Production; Mounting_Type: Surface Mount; Bom_View: https://app.bom.com/a/UCV13EXEXGFM979K?v=bom.indented; Component Kind: Standard; Costing_View: https://app.bom.com/a/UCV13EXEXGFM979K?v=costing.summary; Database Table Name: IC; Datasheet_Link: http://www.ti.com/litv/scds123b; Distributor1: Digi-Key; Distributor_Part_Number1: 296-19204-1-ND; Files_View: https://app.bom.com/a/UCV13EXEXGFM979K?v=files.associations; Library Name: AltiumProductionDatabase.DbLib; Manufacturer_Part_Number1: SN74CBT3253CDBQR; Package_Case: SSOP-16; Part_Number: 1001013; Part_Type: IC; Revision: A; Sourcing_View: https://app.bom.com/a/UCV13EXEXGFM979K?v=sourcing.relationships; Specs_View: https://app.bom.com/a/UCV13EXEXGFM979K?v=spec.summary; Voltage_Supply_Typical: -
U4 = 1001128: IC, Interface, UART-USB, 5V, DFN, 3x3mm; Manufacturer1: FTDI, Future Technology Devices International Ltd; Current_Supply: 8mA; Item_Phase: Production; Operating_Temperature: -40°C ~ 85°C; Voltage_Supply_Max: 5.5V; Voltage_Supply_Min: 2.97V; Bom_View: https://app.bom.com/a/1J28AL4L4NMTGFFD?v=bom.indented; Component Kind: Standard; Costing_View: https://app.bom.com/a/1J28AL4L4NMTGFFD?v=costing.summary; Database Table Name: IC; Datasheet_Link: http://www.ftdichip.com/Support/Documents/DataSheets/ICs/DS_FT234XD.pdf; Distributor1: Digi-Key; Distributor_Part_Number1: 768-1178-1-ND; Files_View: https://app.bom.com/a/1J28AL4L4NMTGFFD?v=files.associations; Library Name: AltiumProductionDatabase.DbLib; Package_Case: 12-VFDFN Exposed Pad; Part_Number: 1001128; Part_Type: IC; Revision: A; Sourcing_View: https://app.bom.com/a/1J28AL4L4NMTGFFD?v=sourcing.relationships; Specs_View: https://app.bom.com/a/1J28AL4L4NMTGFFD?v=spec.summary; Voltage_Supply_Typical: -
